# Altium schematic sheet Paramotor Capacitive Sensor.SchDoc
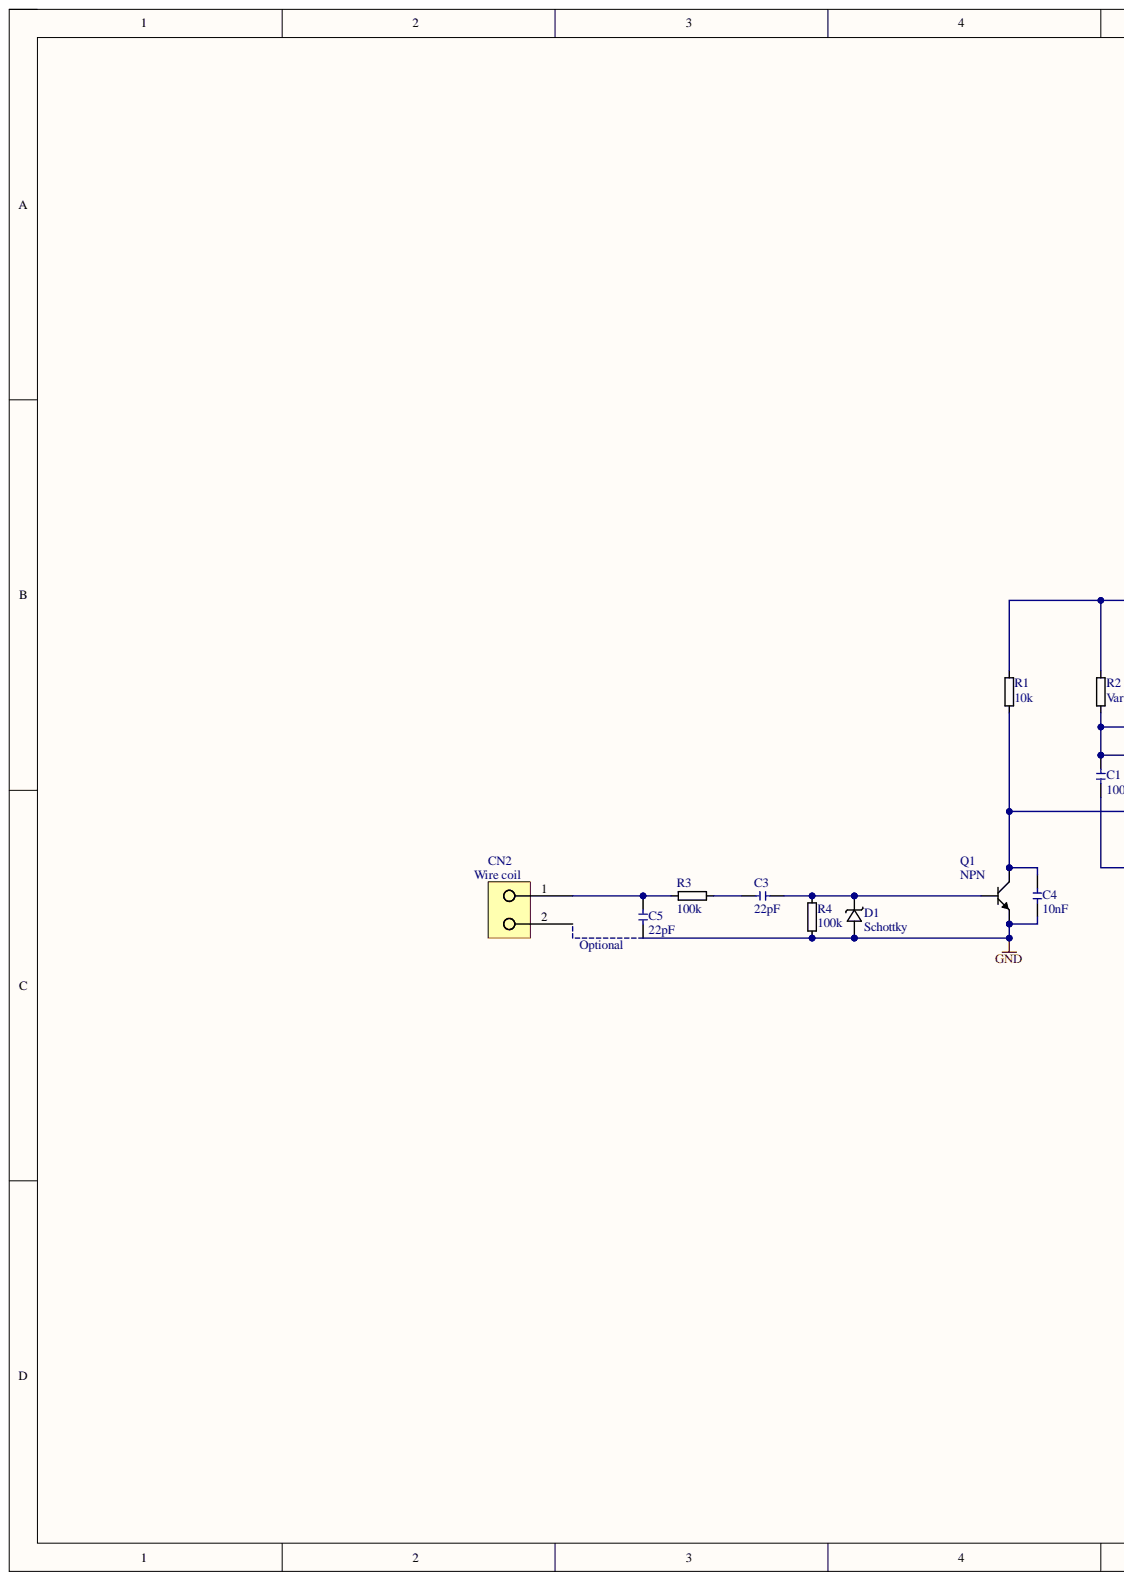
[diagram p0: Paramotor Capacitive Sensor.SchDoc, left]
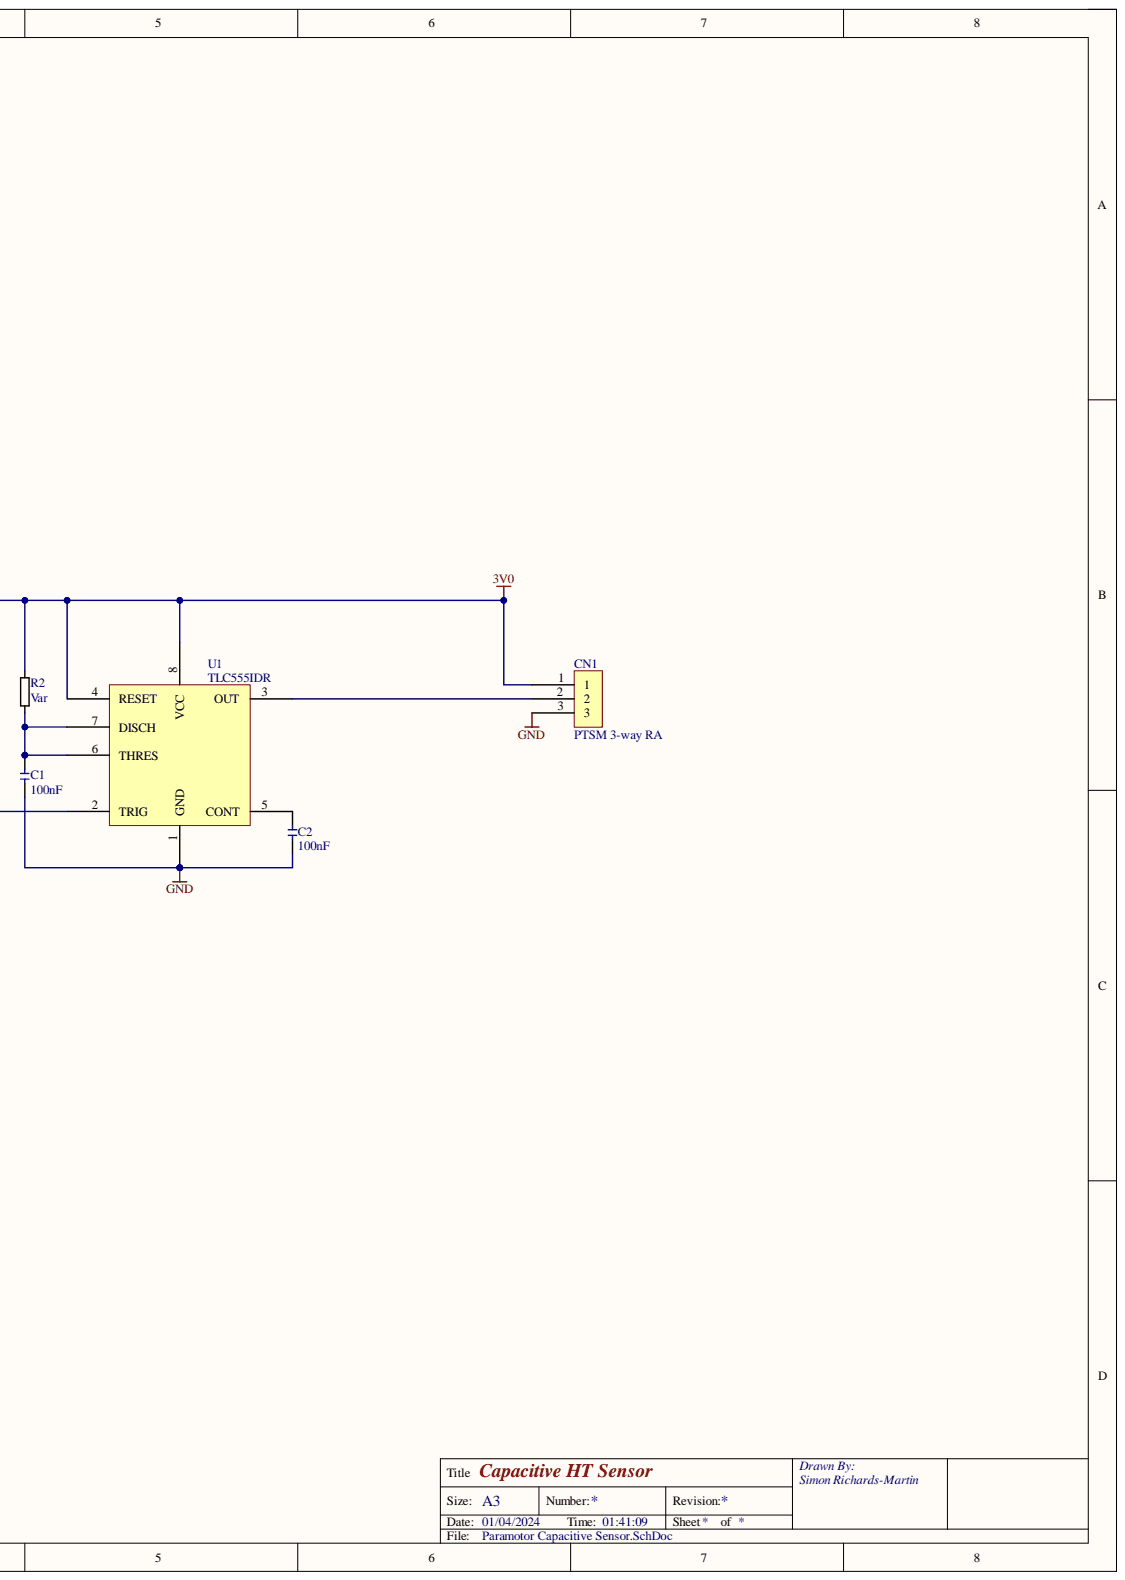
[diagram p1: Paramotor Capacitive Sensor.SchDoc, right]

## Parts
C1  100nF
C2  100nF
C3  22pF
C4  10nF
C5  22pF
CN1  PTSM 3-way RA
CN2  Wire coil
D1  Schottky
Q1  NPN  [SOT23-3]
R1  10k
R2  Var
R3  100k
R4  100k
U1  TLC555IDR

## Nets
3V0: CN1.1 R1.2 R2.2 U1.4(RESET) U1.8(VCC)
GND: C1.2 C2.2 C4.2 C5.1 CN1.3 D1.A Q1.2 R4.1 U1.1(GND)
NetC1_1: C1.1 R2.1 U1.6(THRES) U1.7(DISCH)
NetC2_1: C2.1 U1.5(CONT)
NetC3_1: C3.1 R3.2
NetC3_2: C3.2 D1.K Q1.1 R4.2
NetC4_1: C4.1 Q1.3 R1.1 U1.2(TRIG)
NetC5_2: C5.2 CN2.1 R3.1
NetCN1_2: CN1.2 U1.3(OUT)

Not connected: CN2 2

## Library data of the parts
C1-C5 = Capacitor: Component Kind: Standard; Description: Capacitor; Library Name: Paramotor Inductive Sensor.SchLib
CN1 = PTSM 3-way RA SMT Peg: Component Kind: Standard; Library Name: Paramotor Inductive Sensor.SchLib
CN2 = 2-pin Screw Terminal: Component Kind: Standard; Library Name: Paramotor Inductive Sensor.SchLib
R1-R4 = Resistor: Component Kind: Standard; Description: Resistor; Library Name: Paramotor Inductive Sensor.SchLib
U1 = NE555P: Component Kind: Standard; Library Name: Paramotor Inductive Sensor.SchLib
D1 = Schottky: Component Kind: Standard; Library Name: Paramotor Inductive Sensor.SchLib
Q1 = NPN: Component Kind: Standard; Library Name: Paramotor Capacitive Sensor.SchLib
